# Altium schematic sheet Sheet1.SchDoc(Sheet1)
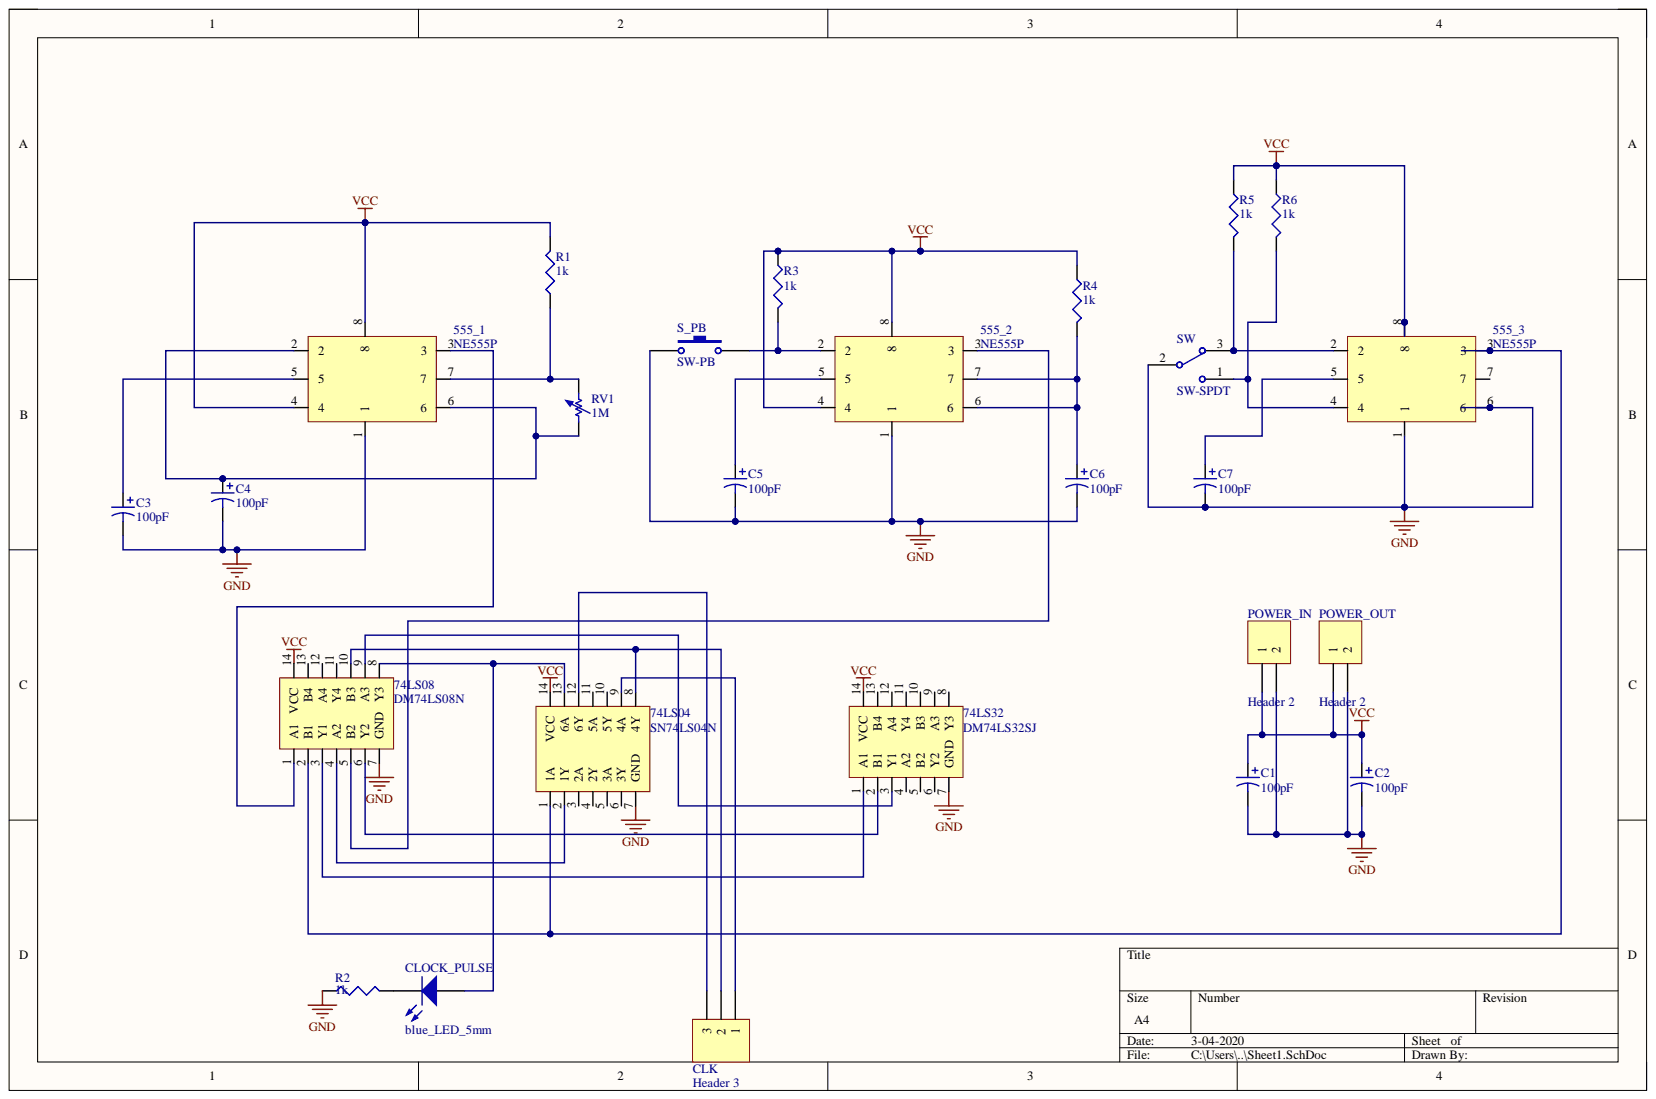
[diagram p0: Sheet1.SchDoc]

## Parts
74LS04  SN74LS04N  [SN74LS04N]
74LS08  DM74LS08N  [SN74LS08N]
74LS32  DM74LS32SJ  [SN74LS32N]
555_1  NE555P  [LM555]
555_2  NE555P  [LM555]
555_3  NE555P  [LM555]
C1  100pF  [C0805]
C2  100pF  [C0805]
C3  100pF  [C0805]
C4  100pF  [C0805]
C5  100pF  [C0805]
C6  100pF  [C0805]
C7  100pF  [C0805]
CLK  Header 3  [HDR1X3]
CLOCK_PULSE  blue_LED_5mm  [HDR1X2]
POWER_IN  Header 2  [HDR1X2]
POWER_OUT  Header 2  [HDR1X2]
R1  1k  [6-0805_L]
R2  1k  [6-0805_L]
R3  1k  [6-0805_L]
R4  1k  [6-0805_L]
R5  1k  [6-0805_L]
R6  1k  [6-0805_L]
RV1  1M  [HDR1X2]
S_PB  SW-PB  [HDR1X2]
SW  SW-SPDT  [HDR1X3]

## Nets
GND: 74LS04.7(GND) 74LS08.7(GND) 74LS32.7(GND) 555_1.1 555_2.1 555_3.1 555_3.6 C1.2 C2.2 C3.2 C4.2 C5.2 C6.2 C7.2 POWER_IN.2 POWER_OUT.2 R2.1 S_PB.1 SW.2
VCC: 74LS04.14(VCC) 74LS08.14(VCC) 74LS32.14(VCC) 555_1.4 555_1.8 555_2.4 555_2.8 555_3.8 C1.1 C2.1 POWER_IN.1 POWER_OUT.1 R1.2 R3.1 R4.1 R5.1 R6.1
Net74LS04_1: 74LS04.1(1A) 74LS08.2(B1) 555_3.3
Net74LS04_2: 74LS04.2(1Y) 74LS08.4(A2)
Net74LS04_8: 74LS04.8(4Y) 74LS08.10(B3) CLK.2
Net74LS04_9: 74LS04.9(4A) CLK.1
Net74LS04_12: 74LS04.12(6Y) CLK.3
Net74LS04_13: 74LS04.13(6A) 74LS08.8(Y3) CLOCK_PULSE.1
Net74LS08_1: 74LS08.1(A1) 555_1.3
Net74LS08_3: 74LS08.3(Y1) 74LS32.1(A1)
Net74LS08_5: 74LS08.5(B2) 555_2.3
Net74LS08_6: 74LS08.6(Y2) 74LS32.2(B1)
Net74LS08_9: 74LS08.9(A3) 74LS32.3(Y1)
Net555_1_2: 555_1.2 555_1.6 C4.1 RV1.1
Net555_1_5: 555_1.5 C3.1
Net555_1_7: 555_1.7 R1.1 RV1.2
Net555_2_2: 555_2.2 R3.2 S_PB.2
Net555_2_5: 555_2.5 C5.1
Net555_2_6: 555_2.6 555_2.7 C6.1 R4.2
Net555_3_2: 555_3.2 R5.2 SW.3
Net555_3_4: 555_3.4 R6.2 SW.1
Net555_3_5: 555_3.5 C7.1
NetCLOCK_PULSE_2: CLOCK_PULSE.2 R2.2

Not connected: 74LS04 3(2A) 4(2Y) 5(3A) 6(3Y) 10(5Y) 11(5A); 74LS08 11(Y4) 12(A4) 13(B4); 74LS32 4(A2) 5(B2) 6(Y2) 8(Y3) 9(A3) 10(B3) 11(Y4) 12(A4) 13(B4); 555_3 7

## Library data of the parts
74LS04 = SN74LS04N: IC HEX INVERTER 14-DIP; Manufacturer: Texas Instruments; High Level Output Current: -400uA; Logic Function: Buffer,Inverter; Low Level Output Current: 8mA; Max Supply Voltage: 5.25V; Min Supply Voltage: 4.75V; Nominal Supply Current: 100uA; Number of Bits: 6; Number of Inputs: 1; Operating Supply Voltage: 5V; Propagation Delay: 15ns; Schmitt Trigger Input: No; Turn-On Delay Time: 22ns; Case/Package: PDIP; Component Kind: Standard; ComponentLink1Description: http://www.ti.com/lit/ds/symlink/sn74ls04.pdf?src-supplier=Digi-Key; ComponentLink1URL: http://www.ti.com/lit/ds/symlink/sn74ls04.pdf?src-supplier=Digi-Key; ComponentLink2Description: http://www.ti.com/general/docs/lit/getliterature.tsp?genericPartNumber=SN54LS04-SP&&fileType=pdf&src-supplier=Avnet; ComponentLink2URL: http://www.ti.com/general/docs/lit/getliterature.tsp?genericPartNumber=SN54LS04-SP&&fileType=pdf&src-supplier=Avnet; ComponentLink3Description: https://www.verical.com/server-webapp/html/getDatasheet?partID=257461&src-supplier=Verical; ComponentLink3URL: https://www.verical.com/server-webapp/html/getDatasheet?partID=257461&src-supplier=Verical; ComponentLink4Description: https://www.distrelec.biz/Web/Downloads/55/06/ix645506.pdf?mime=application%2Fpdf&src-supplier=Distrelec; ComponentLink4URL: https://www.distrelec.biz/Web/Downloads/55/06/ix645506.pdf?mime=application%2Fpdf&src-supplier=Distrelec; ComponentLink5Description: http://www.ti.com/lit/ds/symlink/sn54ls04-sp.pdf?src-supplier=Avnet+Europe; ComponentLink5URL: http://www.ti.com/lit/ds/symlink/sn54ls04-sp.pdf?src-supplier=Avnet+Europe; ComponentLink6Description: http://www.produktinfo.conrad.com/datenblaetter/1000000-1099999/001016869-da-01-en-IC_HEX_INV_SN74LS0_SN74LS04N_PDIP_14_TID.pdf?src-supplier=Conrad; ComponentLink6URL: http://www.produktinfo.conrad.com/datenblaetter/1000000-1099999/001016869-da-01-en-IC_HEX_INV_SN74LS0_SN74LS04N_PDIP_14_TID.pdf?src-supplier=Conrad; ComponentLink7Description: http://www.produktinfo.conrad.com/datenblaetter/150000-174999/172049-da-01-en-IC_SN74_LS_04_N_DIP14_TID.pdf?src-supplier=Conrad; ComponentLink7URL: http://www.produktinfo.conrad.com/datenblaetter/150000-174999/172049-da-01-en-IC_SN74_LS_04_N_DIP14_TID.pdf?src-supplier=Conrad; Contact Plating: Gold; Height: 4.57mm; Lead Free: Lead Free; Length: 19.3mm; Library Name: part_library.SchLib; Manufacturer Part Number: SN74LS04N; Max Operating Temperature: 70°C; Max Output Current: 8mA; Min Operating Temperature: 0°C; Mount: Through Hole; Number of Circuits: 6; Number of Elements: 6; Number of Gates: 6; Number of Output Lines: 6; Number of Pins: 14; Output Current: 8mA; Package Quantity: 25; Packaging: Rail/Tube; Radiation Hardening: No; REACH SVHC: No SVHC; Stock 1: 0; Supplier 1: RSComponents; Supplier Part Number 1: 9232326; Termination: Through Hole; Weight: 0.032734oz; Width: 6.35mm
74LS08 = DM74LS08N: IC GATE AND 4CH 2-INP 14-DIP; Manufacturer: ON Semiconductor / Fairchild; High Level Output Current: -400uA; Low Level Output Current: 8mA; Max Supply Voltage: 5.25V; Min Supply Voltage: 4.75V; Number of Circuits: 4; Number of Inputs: 2; Propagation Delay: 18ns; Case/Package: DIP; Category: Logic Gates; Component Kind: Standard; ComponentLink1Description: https://datasheet.ciiva.com/4825/74ls08-196234-4825713.pdf?src-supplier=Mouser; ComponentLink1URL: https://datasheet.ciiva.com/4825/74ls08-196234-4825713.pdf?src-supplier=Mouser; ComponentLink2Description: https://datasheet.ciiva.com/1877/dm74ls08-1877307.pdf?src-supplier=Digi-Key; ComponentLink2URL: https://datasheet.ciiva.com/1877/dm74ls08-1877307.pdf?src-supplier=Digi-Key; Lead Free: Contains Lead; Library Name: part_library.SchLib; Logic Function: AND; Manufacturer Part Number: DM74LS08N; Max Operating Temperature: 70°C; Min Operating Temperature: 0°C; Mount: Through Hole; Number of Gates: 4; Number of Pins: 14; Package Quantity: 25; Packaging: Rail/Tube; RoHS: Yes; Supplier 1: Mouser; Supplier Part Number 1: 512-DM74LS08N
74LS32 = DM74LS32SJ: Logic Gates Quad 2-Input OR Gate; Manufacturer: ON Semiconductor / Fairchild; High Level Output Current: -400uA; Low Level Output Current: 8mA; Max Supply Voltage: 5.25V; Min Supply Voltage: 4.75V; Number of Gates: 4; Number of Input Lines: 2; Number of Output Lines: 1; Propagation Delay: 15ns; Case/Package: SOP; Category: Logic Gates; Component Kind: Standard; ComponentLink1Description: http://www.mouser.com/ds/2/149/FairchildSemiconductor_16141919504962-795956.pdf?src-supplier=Mouser; ComponentLink1URL: http://www.mouser.com/ds/2/149/FairchildSemiconductor_16141919504962-795956.pdf?src-supplier=Mouser; Library Name: part_library.SchLib; Manufacturer Part Number: DM74LS32SJ; Max Operating Temperature: 70°C; Min Operating Temperature: 0°C; Packaging: Rail/Tube; RoHS: Yes; Supplier 1: Mouser; Supplier Part Number 1: 512-DM74LS32SJ
555_1-555_3 = NE555P: TEXAS INSTRUMENTS         NE555P            TIMER SINGLE PRECISION,DIP8 ,0.5MHZ; Current Rating: 10mA; Frequency: 500kHz; High Level Output Current: -200mA; Low Level Output Current: 200mA; Max Supply Voltage: 16V; Min Supply Voltage: 4.5V; Nominal Supply Current: 2mA; Number of Timers/Counters: 1; Operating Supply Voltage: 5V; Quiescent Current: 15mA; Case/Package: PDIP; Category: Clock and Timing; Component Kind: Standard; ComponentLink1Description: https://datasheet.ciiva.com/19457/904085-19457361.pdf?src-supplier=Jameco; ComponentLink1URL: https://datasheet.ciiva.com/19457/904085-19457361.pdf?src-supplier=Jameco; ComponentLink2Description: https://datasheet.ciiva.com/1139/sa555-1139904.pdf?src-supplier=Element14; ComponentLink2URL: https://datasheet.ciiva.com/1139/sa555-1139904.pdf?src-supplier=Element14; ComponentLink3Description: https://datasheet.ciiva.com/26325/152184-da-01-en-ne555p-timer-tid-26325915.pdf?src-supplier=Conrad; ComponentLink3URL: https://datasheet.ciiva.com/26325/152184-da-01-en-ne555p-timer-tid-26325915.pdf?src-supplier=Conrad; ComponentLink4Description: https://datasheet.ciiva.com/1147/1764032-1147750.pdf?src-supplier=Newark; ComponentLink4URL: https://datasheet.ciiva.com/1147/1764032-1147750.pdf?src-supplier=Newark; ComponentLink5Description: https://datasheet.ciiva.com/14094/ne555-series-eng-tds-14094950.pdf?src-supplier=Distrelec; ComponentLink5URL: https://datasheet.ciiva.com/14094/ne555-series-eng-tds-14094950.pdf?src-supplier=Distrelec; ComponentLink6Description: https://datasheet.ciiva.com/14274/ne555-14274456.pdf?src-supplier=Avnet; ComponentLink6URL: https://datasheet.ciiva.com/14274/ne555-14274456.pdf?src-supplier=Avnet; ComponentLink7Description: https://datasheet.ciiva.com/15152/getdatasheetpartid-248510-15152620.pdf?src-supplier=Verical; ComponentLink7URL: https://datasheet.ciiva.com/15152/getdatasheetpartid-248510-15152620.pdf?src-supplier=Verical; ComponentLink8Description: https://datasheet.ciiva.com/1233/ne555-1233454.pdf?src-supplier=Digi-Key; ComponentLink8URL: https://datasheet.ciiva.com/1233/ne555-1233454.pdf?src-supplier=Digi-Key; ComponentLink9Description: https://datasheet.ciiva.com/26228/ne555-26228892.pdf?src-supplier=Avnet+Europe; ComponentLink9URL: https://datasheet.ciiva.com/26228/ne555-26228892.pdf?src-supplier=Avnet+Europe; Contact Plating: Gold; Height: 4.57mm; Lead Free: Lead Free; Length: 9.81mm; Library Name: part_library.SchLib; Manufacturer: Texas Instruments; Manufacturer Part Number: NE555P; Max Operating Temperature: 70°C; Min Operating Temperature: 0°C; Mount: Through Hole; Number of Pins: 8; Operating Supply Current: 10mA; Package Quantity: 50; Packaging: Rail/Tube; Pricing 1: 1=0,45000, 10=0,34200, 25=0,30800, 100=0,21280, 250=0,17920, 500=0,14560, 1000=0,11200, 2500=0,10080, 5000=0,09520 (USD); Radiation Hardening: No; REACH SVHC: No SVHC; RoHS: Yes; Stock 1: 8033; Supplier 1: Digi-Key; Supplier Part Number 1: 296-NE555P-ND; Weight: 0.015535oz; Width: 6.35mm
C1-C7 = Cap Pol3: Polarized Capacitor (Surface Mount); Component Kind: Standard; LatestRevisionDate: 29-May-2009; LatestRevisionNote: IPC-7351 Footprint Added.; Library Name: Miscellaneous Devices.IntLib; PackageDescription: Chip Capacitor; PackageReference: C0805; Published: 8-Jun-2000; Signal Integrity: Cap Pol; Simulation: CAP; Value: 100pF; Publisher: Altium Limited
CLK = Header 3: Header, 3-Pin; Component Kind: Standard; LatestRevisionDate: 17-Jul-2002; LatestRevisionNote: Re-released for DXP Platform.; Library Name: Miscellaneous Connectors.IntLib; Signal Integrity: Connector; Publisher: Altium Limited
CLOCK_PULSE = LED0: Typical INFRARED GaAs LED; Component Kind: Standard; LatestRevisionDate: 08-Jul-2005; LatestRevisionNote: Mech Layer 1 removed.; Library Name: Miscellaneous Devices.IntLib; PackageDescription: LED; 2 Leads; PackageReference: LED-0; Published: 8-Jun-2000; Simulation: LED0; Publisher: Altium Limited
POWER_IN POWER_OUT = Header 2: Header, 2-Pin; Component Kind: Standard; LatestRevisionDate: 17-Jul-2002; LatestRevisionNote: Re-released for DXP Platform.; Library Name: Miscellaneous Connectors.IntLib; Signal Integrity: Connector; Publisher: Altium Limited
R1-R6 = Res3: Resistor; Component Kind: Standard; LatestRevisionDate: 29-May-2009; LatestRevisionNote: IPC-7351 Footprint Added.; Library Name: Miscellaneous Devices.IntLib; PackageDescription: Chip Resistor; PackageReference: J1-0603; Published: 8-Jun-2000; Signal Integrity: Res; Simulation: RESISTOR; Value: 1K; Publisher: Altium Limited
RV1 = Res Adj1: Variable Resistor; Set Position: 0.5; Value: 1K; Component Kind: Standard; LatestRevisionDate: 17-Jul-2002; LatestRevisionNote: Re-released for DXP Platform.; Library Name: Miscellaneous Devices.IntLib; PackageDescription: Axial Device, Thru-Hole; 2 Leads; 0.7 in Pin Spacing; PackageReference: AXIAL-0.7; Published: 8-Jun-2000; Signal Integrity: Res Adj; Simulation: VRES; Publisher: Altium Limited
S_PB = SW-PB: Switch; Component Kind: Standard; LatestRevisionDate: 17-Jul-2002; LatestRevisionNote: Re-released for DXP Platform.; Library Name: Miscellaneous Devices.IntLib; PackageDescription: Switch; 2 Leads; PackageReference: SPST-2; Published: 24-Mar-1999; Publisher: Altium Limited
SW = SW-SPDT: SPDT Subminiature Toggle Switch, Right Angle Mounting, Vertical Actuation; Component Kind: Standard; ComponentLink1Description: Manufacturer Link; ComponentLink1URL: http://www.apem.com; DatasheetVersion: 05-Dec-2000; LatestRevisionDate: 17-Dec-2003; LatestRevisionNote: Schematic revised, PCB Footprint replaced.; Library Name: Miscellaneous Devices.IntLib; PackageDescription: Switch; 4 Leads; PackageReference: TL36WW15050; Published: 24-Mar-1999; Publisher: Altium Limited
